# Altium schematic sheet StEth32_Base_Power_Output.SchDoc
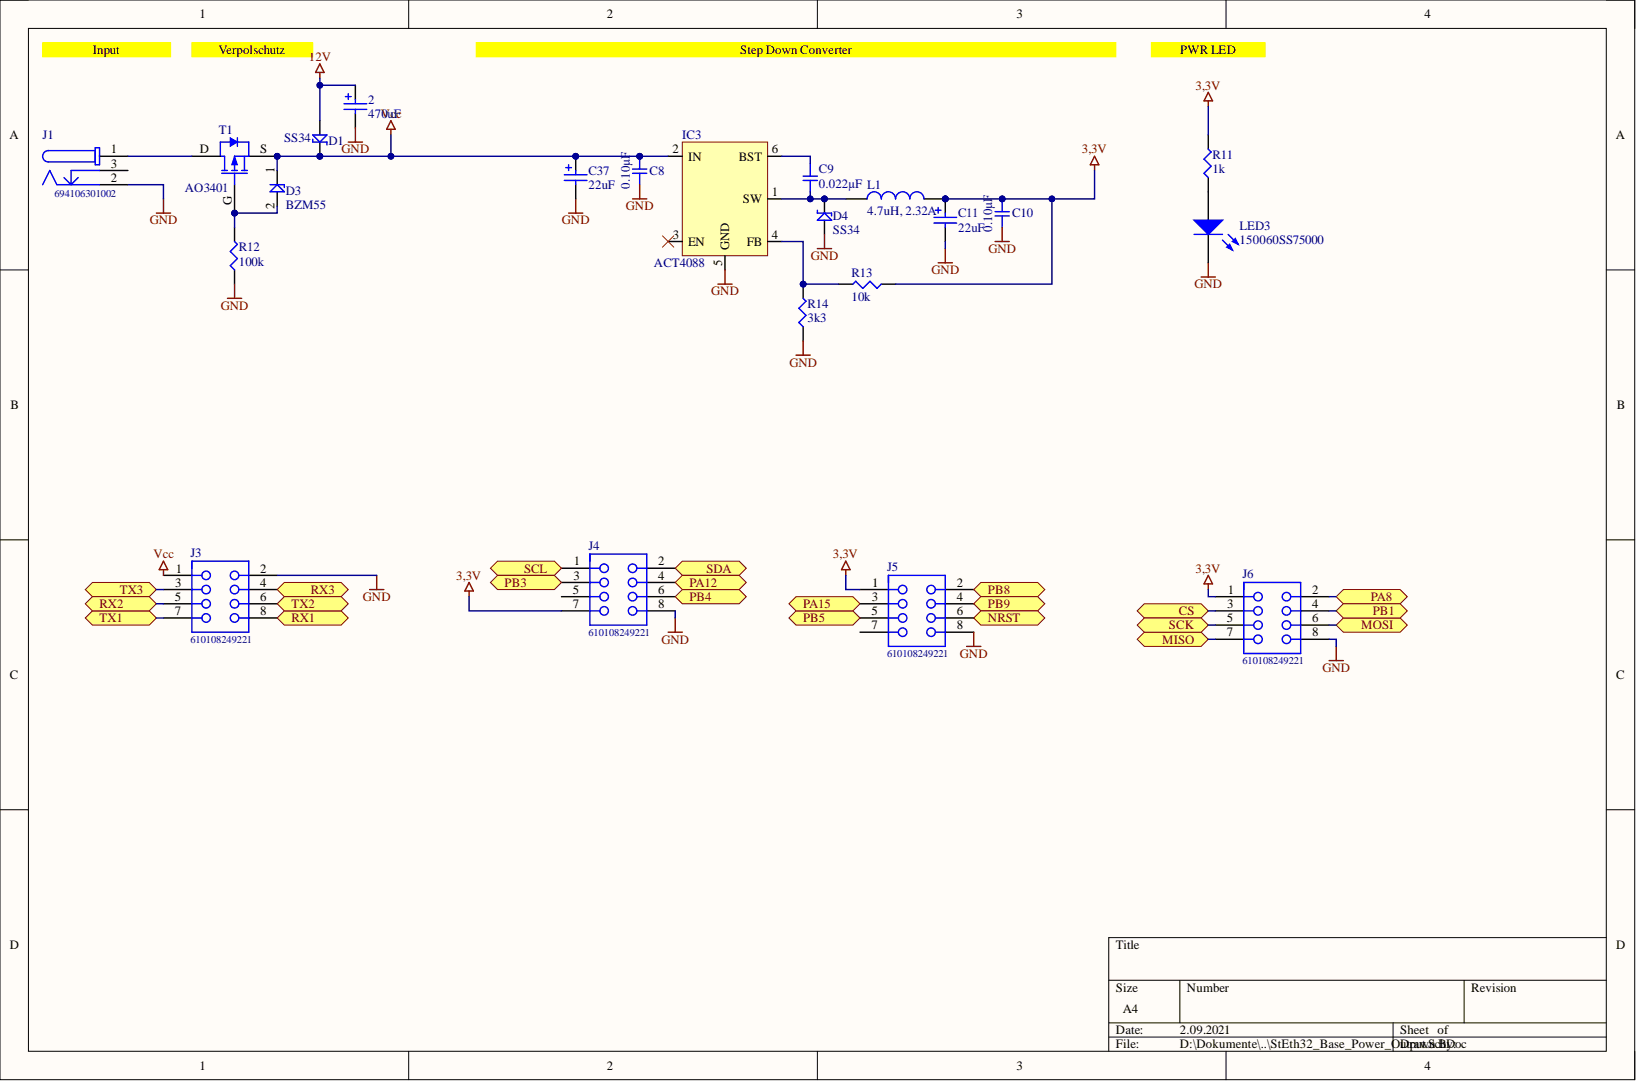
[diagram p0: StEth32_Base_Power_Output.SchDoc]

## Parts
2  value 470uF  [WCAP-ASLI_10x10.5]  (hidden comment: 865080457015)
C8  0.10µF  [SMD-0603C]
C9  0.022µF  [SMD-0603C]
C10  0.10µF  [SMD-0603C]
C11  value 22uF  [WCAP-ASLI_4x5.5]  (hidden comment: 865080140001)
C37  value 22uF  [WCAP-ASLI_4x5.5]  (hidden comment: 865080140001)
D1  SS34  [B240A]
D3  BZM55  [SMD-0603-RES]
D4  SS34  [B240A]
IC3  ACT4088  [SOT23-6]
J1  694106301002  [694106301002]
J3  610108249221  [610108249221]
J4  610108249221  [610108249221]
J5  610108249221  [610108249221]
J6  610108249221  [610108249221]
L1  4.7uH, 2.32A  [WE-PD_7332]
LED3  150060SS75000  [0603_A]
R11  1k  [SMD-0603-RES]
R12  100k  [SMD-0603-RES]
R13  10k  [SMD-0603-RES]
R14  3k3  [SMD-0603-RES]
T1  AO3401  [SOT23]

## Ports
CS, MISO, MOSI, NRST, PA8, PA12, PA15, PB1, PB3, PB4, PB5, PB8, PB9, RX1, RX2, RX3, SCK, SCL, SDA, TX1, TX2, TX3

## Nets
3,3V: C10.1 C11.1 J4.7 J5.1 J6.1 L1.2 R11.2 R13.1
12V: 2.1 D1.A
GND: 2.2 C8.2 C10.2 C11.2 C37.2 D4.A IC3.5(GND) J1.2 J3.2 J4.8 J5.8 J6.8 LED3.1 R12.1 R14.1
Vcc: C8.1 C37.1 D1.K D3.1 IC3.2(IN) J3.1 T1.S
CS: J6.3 ; port CS
MISO: J6.7 ; port MISO
MOSI: J6.6 ; port MOSI
NRST: J5.6 ; port NRST
PA8: J6.2 ; port PA8
PA12: J4.4 ; port PA12
PA15: J5.3 ; port PA15
PB1: J6.4 ; port PB1
PB3: J4.3 ; port PB3
PB4: J4.6 ; port PB4
PB5: J5.5 ; port PB5
PB8: J5.2 ; port PB8
PB9: J5.4 ; port PB9
RX1: J3.8 ; port RX1
RX2: J3.5 ; port RX2
RX3: J3.4 ; port RX3
SCK: J6.5 ; port SCK
SCL: J4.1 ; port SCL
SDA: J4.2 ; port SDA
TX1: J3.7 ; port TX1
TX2: J3.6 ; port TX2
TX3: J3.3 ; port TX3
NetC9_1: C9.1 IC3.6(BST)
NetC9_2: C9.2 D4.K IC3.1(SW) L1.1
NetD3_2: D3.2 R12.2 T1.G
NetIC3_4: IC3.4(FB) R13.2 R14.2
NetJ1_1: J1.1 T1.D
NetLED3_2: LED3.2 R11.1

Not connected: IC3 3(EN); J1 3; J4 5; J5 7

## Library data of the parts
2 = 865080457015: WCAP-ASLI Aluminum Electrolytic Capacitors, size Ф10x10.5mm, 25V DC, 470uF; Rated Voltage: 25V DC; Component Kind: Standard; ComponentLink1Description: Manufacturer; ComponentLink1URL: http://www.we-online.de; ComponentLink2Description: Datasheet; ComponentLink2URL: http://katalog.we-online.de/pbs/datasheet/865080457015.pdf; Library Name: ALTIUM-WCAP-ASLI-rev17a.IntLib; Life Cycle: 2000h; Operating Temperature: -55 °C up to +105 °C; Part Number: 865080457015; Published Date: June 2017; Size: Ф10x10.5mm; Publisher: Wurth Elektronik
C8 C10 = GCM188R71C104KA37J: CAP CER 0.1UF 16V X7R 0603; Manufacturer: Murata Electronics North America; @ qty: 0; Minimum Quantity: 1; Tolerance: ±10%; Unit Price (USD): 0.18; Applications: Automotive; Component Kind: Standard; Datasheets: http://www.murata.com/~/media/webrenewal/support/library/catalog/products/capacitor/mlcc/c03e.ashx; Digi-Key Part Number: 490-8015-1-ND; Factory Stock: 0; Features: -; Height - Seated (Max): -; Image: //media.digikey.com/Renders/Murata%20Renders/0603%28GCM188%29.jpg; Lead Spacing: -; Lead Style: -; Library Name: capacitor_murata_0603.IntLib; Mounting Type: Surface Mount, MLCC; Operating Temperature: -55°C ~ 125°C; Package / Case: 0603 (1608 Metric); Packaging: Cut Tape (CT); Part Number: GCM188R71C104KA37J; Part Status: Active; Quantity Available: 17844; Ratings: AEC-Q200; Series: GCM; Size / Dimension: 0.063" L x 0.031" W (1.60mm x 0.80mm); Temperature Coefficient: X7R; Thickness (Max): 0.035" (0.90mm); Value: 0.10µF; Voltage: 16V
C9 = GCJ188R71H223KA01D: CAP CER 0.022UF 50V X7R 0603; Manufacturer: Murata Electronics North America; @ qty: 0; Minimum Quantity: 1; Tolerance: ±10%; Unit Price (USD): 0.22; Applications: Automotive, Boardflex Sensitive; Component Kind: Standard; Datasheets: http://www.murata.com/~/media/webrenewal/support/library/catalog/products/capacitor/mlcc/c03e.ashx; Digi-Key Part Number: 490-5851-1-ND; Factory Stock: 0; Features: Soft Termination; Height - Seated (Max): -; Image: //media.digikey.com/Renders/Murata%20Renders/0603%28LQG18%29.jpg; Lead Spacing: -; Lead Style: -; Library Name: capacitor_murata_0603.IntLib; Mounting Type: Surface Mount, MLCC; Operating Temperature: -55°C ~ 125°C; Package / Case: 0603 (1608 Metric); Packaging: Cut Tape (CT); Part Number: GCJ188R71H223KA01D; Part Status: Active; Quantity Available: 7651; Ratings: AEC-Q200; Series: GCJ; Size / Dimension: 0.063" L x 0.031" W (1.60mm x 0.80mm); Temperature Coefficient: X7R; Thickness (Max): 0.031" (0.80mm); Value: 0.022µF; Voltage: 50V
C11 C37 = 865080140001: WCAP-ASLI Aluminum Electrolytic Capacitors, size Ф4x5.5mm, 6.3V DC, 22uF; Rated Voltage: 6.3V DC; Component Kind: Standard; ComponentLink1Description: Manufacturer; ComponentLink1URL: http://www.we-online.de; ComponentLink2Description: Datasheet; ComponentLink2URL: http://katalog.we-online.de/pbs/datasheet/865080140001.pdf; Library Name: ALTIUM-WCAP-ASLI-rev17a.IntLib; Life Cycle: 2000h; Operating Temperature: -55 °C up to +105 °C; Part Number: 865080140001; Published Date: June 2017; Size: Ф4x5.5mm; Publisher: Wurth Elektronik
D1 D4 = B240A: Shottkydiode; Component Kind: Standard; Library Name: MyLibs.SchLib
J1 = 694106301002: DC Power Jack Connector WR-DC, Male, Right Angled, THT, 5 A, 24 V; Opening Diameter: 6.4 mm; Plug Inner Diameter: 2.05 mm; Plug Outer Diameter: 5.5 mm; Rated Current: 5 A; Working Voltage: 24 V; Component Kind: Standard; ComponentLink1Description: Manufacturer; ComponentLink1URL: http://www.we-online.de; ComponentLink2Description: Datasheet; ComponentLink2URL: http://katalog.we-online.de/em/datasheet/6941xx301002.pdf; Gender: Male; Library Name: 694106301002_Altium_WR-DC_POWER_JACK_CONNECTORS_rev18b.IntLib; Mounting Technology: THT; Operating Temperature: -40 to 85 degC; Orientation: Right Angled; Packaging: Bag; Part Number: 694106301002; Published Date: August 2018; Publisher: Wurth Elektronik
J3-J6 = 610108249221: WR-PHD Socket Header, SMT, pitch 2.54mm, Dual Row, Angled, 8pin; Contact Resistance: 20mOhm; Gender: Female; Pin Number: 8; Rated Current: 3A; Withstanding Voltage: 600VAC; Working Voltage: 250VAC; Component Kind: Standard; ComponentLink1Description: Manufacturer; ComponentLink1URL: http://www.we-online.de; ComponentLink2Description: Datasheet; ComponentLink2URL: https://katalog.we-online.de/em/datasheet/6101xx249221.pdf; Library Name: o155175v243 Altium_WR-PHD (rev19a).IntLib; Mounting Technology: SMT; Operating Temperature: -40 to +105degC; Orientation: Angled; Packaging: Tape and Reel; Part Number: 610108249221; Pitch: 2.54mm; Published Date: June 2019; Row Number: Dual; Publisher: Wurth Elektronik
L1 = 744778004: WE-PD SMT Power Inductor, size 7332, 4.7uH, 2.32A; DC Resistance max.: 60mOhm; Saturation Current: 4.2A; Self Resonant Frequency: 45MHz; Component Kind: Standard; ComponentLink1Description: Manufacturer; ComponentLink1URL: http://www.we-online.de; ComponentLink2Description: Datasheet; ComponentLink2URL: https://katalog.we-online.com/pbs/datasheet/744778004.pdf; Inductance: 4.7uH; Library Name: ALTIUM-WE-PD-rev19b.IntLib; Mounting Technology: SMT; Operating Temperature: -40 to +125degC; Packaging: Tape and Reel; Part Number: 744778004; Published Date: April 2019; Rated Current: 2.32A; Size: 7332; Publisher: Wurth Elektronik
LED3 = 150060SS75000: SMD mono-color Chip LED, WL-SMCW, Super Red; Color: Super Red; Component Kind: Standard; ComponentLink1Description: Manufacturer URL; ComponentLink1URL: http://www.we-online.com; ComponentLink2Description: Datasheet; ComponentLink2URL: http://katalog.we-online.com/led/datasheet/150060SS75000.pdf; PackageReference: 0603_A; PartNumber: 150060SS75000; Library Name: WL-SMCW SMD chip LED top view mono-color waterclear.IntLib
R11 R13 R14 = ERJ-3EKF1001V: RES SMD 1K OHM 1% 1/10W 0603; Manufacturer: Panasonic Electronic Components; @ qty: 1500; Factory Stock: 0; Minimum Quantity: 1; Power (Watts): 0.1W, 1/10W; Temperature Coefficient: ±100ppm/°C; Unit Price (USD): 0.00379; Component Kind: Standard; Composition: Thick Film; Datasheets: http://industrial.panasonic.com/www-cgi/jvcr13pz.cgi?E+PZ+3+AOA0002+ERJ3EKF1001V+7+WW; Digi-Key Part Number: P1.00KHCT-ND; Failure Rate: -; Features: Automotive AEC-Q200; Height - Seated (Max): 0.022" (0.55mm); Image: //media.digikey.com/Photos/Panasonic%20Photos/MFG_ERJ-P6W%20Series.jpg; Library Name: Panasonic_ERJ_0603.IntLib; Number of Terminations: 2; Operating Temperature: -55°C ~ 155°C; Package / Case: 0603 (1608 Metric); Packaging: Cut Tape (CT); Part Number: ERJ-3EKF1001V; Part Status: Active; Quantity Available: 5218845; Series: ERJ; Size / Dimension: 0.063" L x 0.031" W (1.60mm x 0.80mm); Supplier Device Package: 0603; Tolerance: ±1%
  R11: Value: 1k
  R13: Value: 10k
  R14: Value: 3k3
R12 = ERJ-3EKF4701V: RES SMD 4.7K OHM 1% 1/10W 0603; Manufacturer: Panasonic Electronic Components; @ qty: 1500; Factory Stock: 0; Minimum Quantity: 1; Power (Watts): 0.1W, 1/10W; Temperature Coefficient: ±100ppm/°C; Unit Price (USD): 0.00379; Component Kind: Standard; Composition: Thick Film; Datasheets: http://industrial.panasonic.com/www-cgi/jvcr13pz.cgi?E+PZ+3+AOA0002+ERJ3EKF4701V+7+WW; Digi-Key Part Number: P4.70KHCT-ND; Failure Rate: -; Features: Automotive AEC-Q200; Height - Seated (Max): 0.022" (0.55mm); Image: //media.digikey.com/Photos/Panasonic%20Photos/MFG_ERJ-P6W%20Series.jpg; Library Name: Panasonic_ERJ_0603.IntLib; Number of Terminations: 2; Operating Temperature: -55°C ~ 155°C; Package / Case: 0603 (1608 Metric); Packaging: Cut Tape (CT); Part Number: ERJ-3EKF4701V; Part Status: Active; Quantity Available: 999380; Series: ERJ; Size / Dimension: 0.063" L x 0.031" W (1.60mm x 0.80mm); Supplier Device Package: 0603; Tolerance: ±1%; Value: 100k
T1 = AO3401: AO3401 MOSFET 30V; Component Kind: Standard; Library Name: MyLibs.SchLib
D3 = BZM55: Component Kind: Standard; Library Name: MyLibs.SchLib
IC3 = ACT4088: Component Kind: Standard; Library Name: MyLibs.SchLib
